# Altium schematic sheet BLE.SchDoc
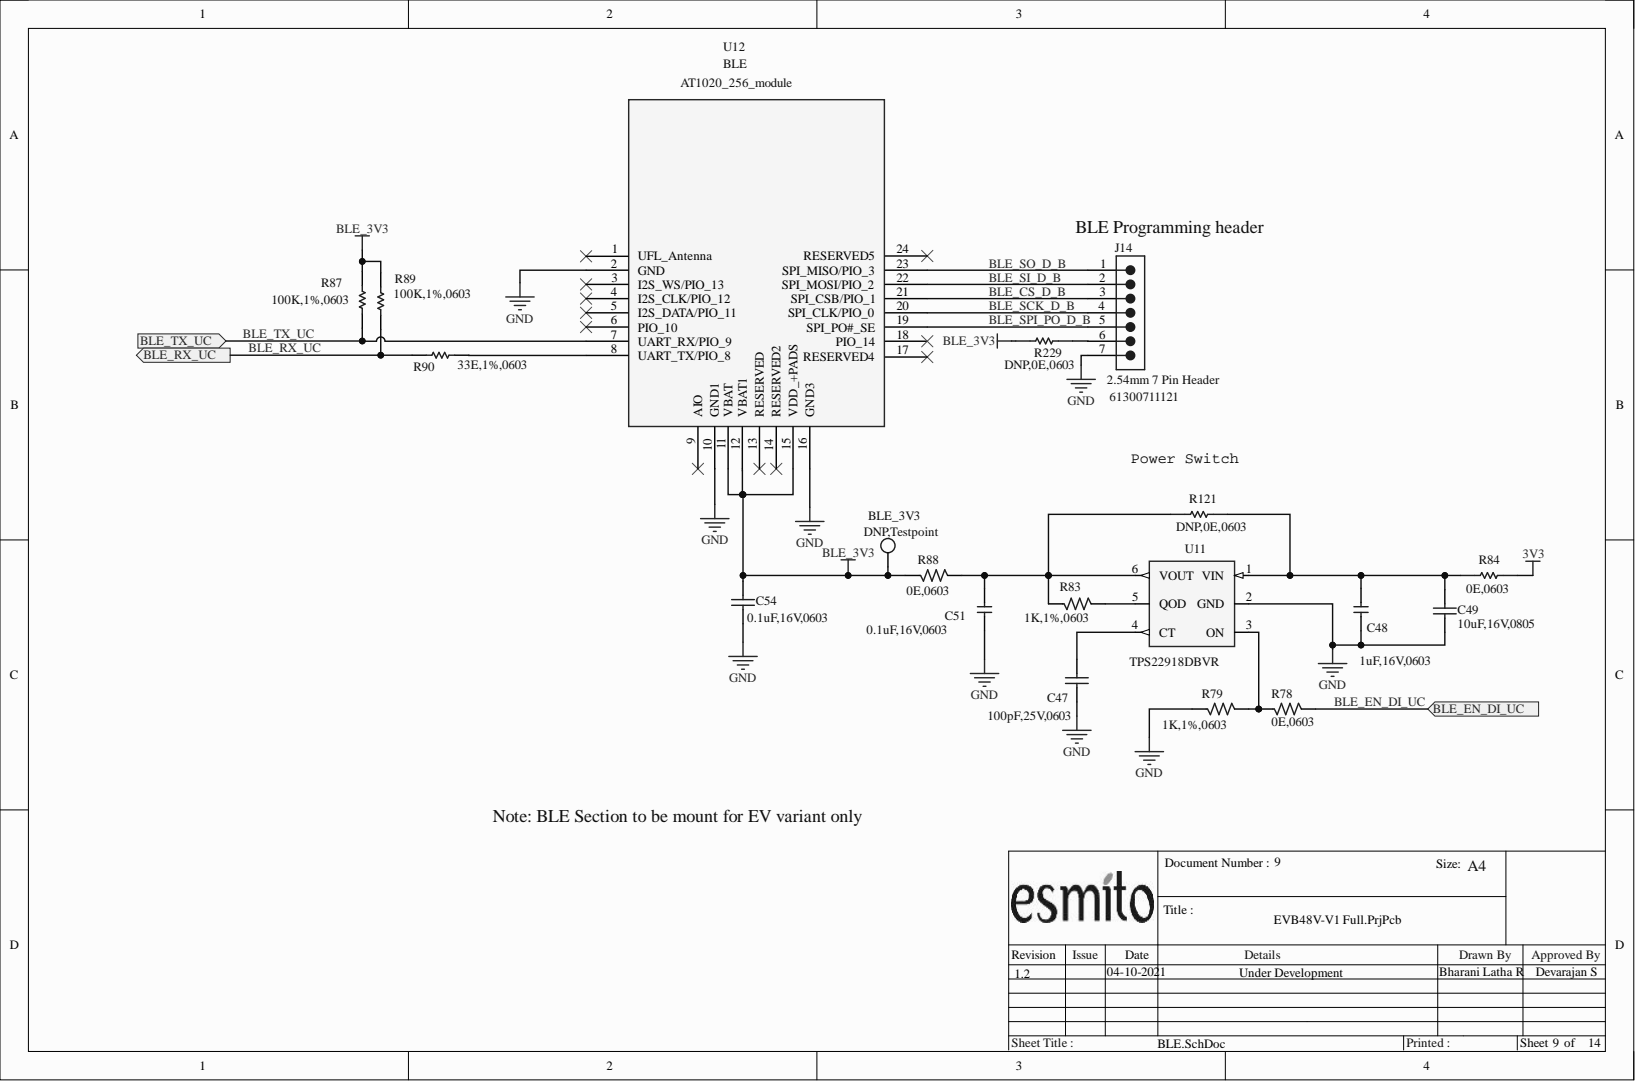
[diagram p0: BLE.SchDoc]

## Parts
BLE_3V3  value DNP,Testpoint  [Keystone5002]  (hidden comment: TEST POINT)
C47  value 100pF,25V,0603  [0603_C_M]  (hidden comment: C_Semi)
C48  1uF,16V,0603  [0603_C_M]
C49  value 10uF,16V,0805  [0805_R_M]  (hidden comment: C_Semi)
C51  0.1uF,16V,0603  [0603_C_M]
C54  value 0.1uF,16V,0603  [0603_C_M]  (hidden comment: C_Semi)
J14  2.54mm 7 Pin Header  value 61300711121  [61300711121]
R78  0E,0603  [0603_R_M]
R79  1K,1%,0603  [0603_R_M]
R83  1K,1%,0603  [0603_R_M]
R84  value 0E,0603  [0603_R_M]  (hidden comment: R_Semi)
R87  value 100K,1%,0603  [0603_R_M]  (hidden comment: R_Semi)
R88  0E,0603  [0603_R_M]
R89  value 100K,1%,0603  [0603_R_M]  (hidden comment: R_Semi)
R90  value 33E,1%,0603  [0603_R_M]  (hidden comment: R_Semi)
R121  value DNP,0E,0603  [0603_R_M]  (hidden comment: R_Semi)
R229  value DNP,0E,0603  [0603_R_M]  (hidden comment: R_Semi)
U11  TPS22918DBVR  [SOT95P280X145-6N]
U12  BLE  value AT1020_256_module  Part Number: AT1020_256_module  [AT1020_256_1]

## Ports
BLE_EN_DI_UC, BLE_RX_UC, BLE_TX_UC

## Nets
3V3: R84.2
BLE_3V3: BLE_3V3.1 C54.2 R87.2 R88.1 R89.2 R229.1 U12.11(VBAT) U12.12(VBAT1) U12.15(VDD_+PADS)
GND: C47.1 C48.1 C49.1 C51.1 C54.1 J14.7 R79.1 U11.2(GND) U12.2(GND) U12.10(GND1) U12.16(GND3)
BLE_CS_D_B: J14.3 U12.21(SPI_CSB/PIO_1)
BLE_EN_DI_UC: R78.2 ; port BLE_EN_DI_UC
BLE_RX_UC: R89.1 R90.2 ; port BLE_RX_UC
BLE_SCK_D_B: J14.4 U12.20(SPI_CLK/PIO_0)
BLE_SI_D_B: J14.2 U12.22(SPI_MOSI/PIO_2)
BLE_SO_D_B: J14.1 U12.23(SPI_MISO/PIO_3)
BLE_SPI_PO_D_B: J14.5 U12.19(SPI_PO#_SE)
BLE_TX_UC: R87.1 U12.7(UART_RX/PIO_9) ; port BLE_TX_UC
NetC47_2: C47.2 U11.4(CT)
NetC48_2: C48.2 C49.2 R84.1 R121.2 U11.1(VIN)
NetC51_2: C51.2 R83.1 R88.2 R121.1 U11.6(VOUT)
NetJ14_6: J14.6 R229.2
NetR78_1: R78.1 R79.2 U11.3(ON)
NetR83_2: R83.2 U11.5(QOD)
NetR90_1: R90.1 U12.8(UART_TX/PIO_8)

Not connected: U12 1(UFL_Antenna) 3(I2S_WS/PIO_13) 4(I2S_CLK/PIO_12) 5(I2S_DATA/PIO_11) 6(PIO_10) 9(AIO) 13(RESERVED) 14(RESERVED2) 17(RESERVED4) 18(PIO_14) 24(RESERVED5)

## Library data of the parts
BLE_3V3 = T POINT R: PCB TEST POINT BLACK 1mm; Manufacturer: Keystone Electronics; Part Number: 5001; Component Kind: Standard
C47 = C_Semi: MLCC - 0603 25V 100pF X7R 10%; Manufacturer: AVX; Part Number: 06033C101K4T2A; Component Kind: Standard; Signal Integrity: Cap Semi; Simulation: CAP; Library Name: Grinntech_Global_Library.IntLib
C48 = C_11: MLCC - 0603 1uF 16V X5R 10%; Alternate Manufacturer: Murata; Alternate Part Number: GCM188R71C105KA64D; Manufacturer: Yageo; Part Number: CC603KRX5R7BB105; Component Kind: Standard; Value: 1uF,16V,0603
C49 = C_Semi: MLCC - 0805 16V 10uF X7R 10 %; Alternate Manufacturer: Taiyo Yuden; Alternate Part Number: EMK212BB7106KG-T; Manufacturer: Yageo; Part Number: CC0805KKX7R7BB106; Component Kind: Standard; Signal Integrity: Cap Semi; Simulation: CAP; Library Name: Grinntech_Global_Library.IntLib
C51 = C_11: MLCC - 0603 100nF 16V X7R 10%; Alternate Manufacturer: AVX; Alternate Part Number: 0603YC104K4T2A; Manufacturer: Yageo; Part Number: CC603KRX7R7BB104; Component Kind: Standard; Simulation: C_11; Value: 0.1uF,16V,0603
C54 = C_Semi: MLCC - 0603 100nF 16V X7R 10%; Alternate Manufacturer: AVX; Alternate Part Number: 0603YC104K4T2A; Manufacturer: Yageo; Part Number: CC603KRX7R7BB104; Component Kind: Standard; Signal Integrity: Cap Semi; Simulation: CAP; Library Name: Grinntech_Global_Library.IntLib
J14 = 61300711121: WR-PHD Pin Header, THT, pitch 2.54mm, Single Row, Vertical, 7p; Manufacturer: Wurth Elektronik; Component Kind: Standard; Part Number: 61300711121; Library Name: AFE_UC_Active_Conn.SchLib
R78 R88 = R_1: R, THK FLM, 0E,100mW,  0603; Alternate Manufacturer: Vishay; Alternate Part Number: CRCW06030000Z0EAC; Manufacturer: Yageo; Part Number: RC0603FR-070RL; Component Kind: Standard; Value: 0E,0603
R79 R83 = R_1: R, THK FLM, 1K, 1%, 100mW, 0603; Alternate Manufacturer: Vishay; Alternate Part Number: CRCW06031K00FKEA; Manufacturer: Yageo; Part Number: RC0603FR-071KL; Component Kind: Standard; Value: 1K,1%,0603
  R83: Simulation: R_1
R84 R121 R229 = R_Semi_R245: R, THK FLM, 0E,100mW,  0603; Alternate Manufacturer: Vishay; Alternate Part Number: CRCW06030000Z0EAC; Manufacturer: Yageo; Part Number: RC0603FR-070RL; Component Kind: Standard; Library Name: CLVESB-R10.SCHLIB
R87 R89 = R_Semi: R, THK FLM, 100K, 1%, 100mW, 0603; Alternate Manufacturer: Vishay; Alternate Part Number: CRCW0603100KFKEA; Manufacturer: Yageo; Part Number: RC0603FR-07100KL; Component Kind: Standard; Library Name: Grinntech_Global_Library.IntLib
R90 = R_Semi: R, THK FLM, 33E, 1%, 100mW, 0603; Alternate Manufacturer: Yageo; Alternate Part Number: AC0603FR-0733RL; Part Number: RC0603FR-0733RL; Component Kind: Standard; Manufacturer: Yageo; Library Name: Grinntech_Global_Library.IntLib
U11 = C78L32/SO_2: Power Switch  5.5V 2A; Alternate Manufacturer: Texas Instruments; Alternate Part Number: TPS22918TDBVTQ1; Component Kind: Standard; Manufacturer: Texas Instruments; Part Number: TPS22918DBVR; Value: TPS22918DBVR
U12 = BLE_Module_AT1020-256_U16: Low Power BLE module; Manufacturer: Atoll; Component Kind: Standard; Library Name: CLVESB-R10.SCHLIB
